# Altium schematic sheet A9_PERFBOARD.SchDoc
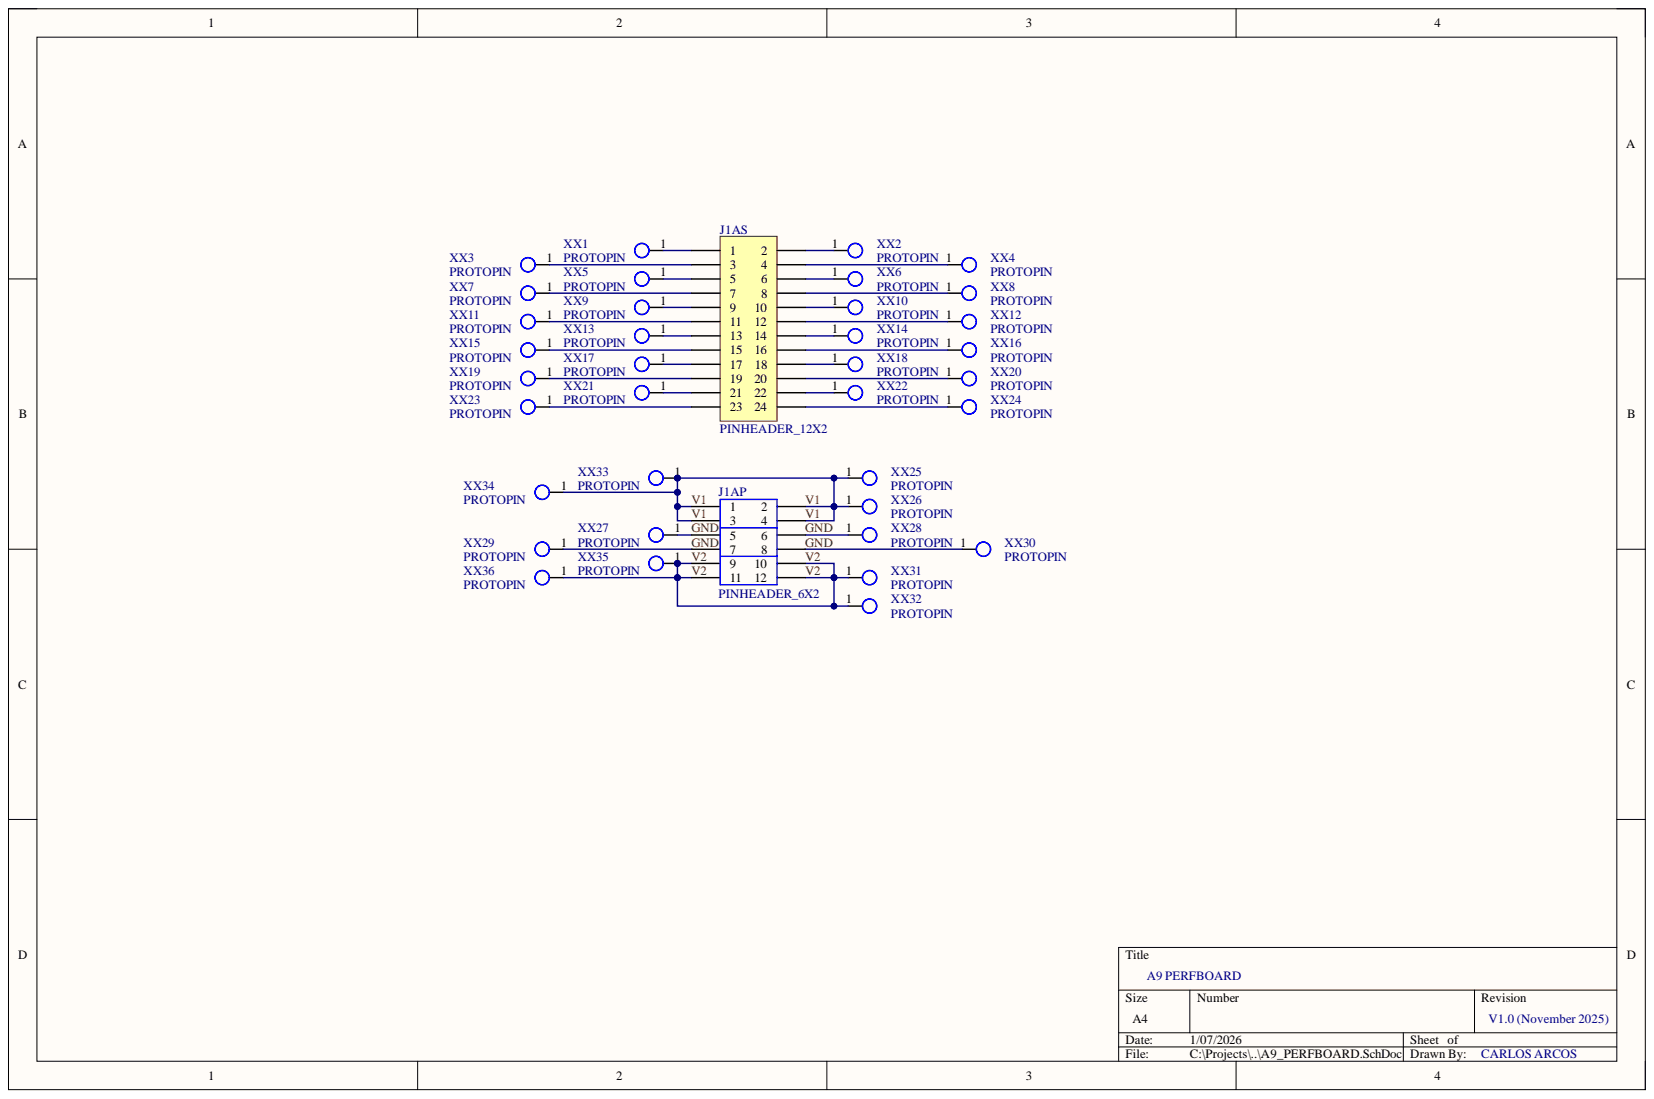
[diagram p0: A9_PERFBOARD.SchDoc]

## Parts
J1AP  PINHEADER_6X2  [PINHEADER_6X2]
J1AS  PINHEADER_12X2  [PINHEADER_12X2]
XX1  PROTOPIN  [PROTOPIN]
XX2  PROTOPIN  [PROTOPIN]
XX3  PROTOPIN  [PROTOPIN]
XX4  PROTOPIN  [PROTOPIN]
XX5  PROTOPIN  [PROTOPIN]
XX6  PROTOPIN  [PROTOPIN]
XX7  PROTOPIN  [PROTOPIN]
XX8  PROTOPIN  [PROTOPIN]
XX9  PROTOPIN  [PROTOPIN]
XX10  PROTOPIN  [PROTOPIN]
XX11  PROTOPIN  [PROTOPIN]
XX12  PROTOPIN  [PROTOPIN]
XX13  PROTOPIN  [PROTOPIN]
XX14  PROTOPIN  [PROTOPIN]
XX15  PROTOPIN  [PROTOPIN]
XX16  PROTOPIN  [PROTOPIN]
XX17  PROTOPIN  [PROTOPIN]
XX18  PROTOPIN  [PROTOPIN]
XX19  PROTOPIN  [PROTOPIN]
XX20  PROTOPIN  [PROTOPIN]
XX21  PROTOPIN  [PROTOPIN]
XX22  PROTOPIN  [PROTOPIN]
XX23  PROTOPIN  [PROTOPIN]
XX24  PROTOPIN  [PROTOPIN]
XX25  PROTOPIN  [PROTOPIN]
XX26  PROTOPIN  [PROTOPIN]
XX27  PROTOPIN  [PROTOPIN]
XX28  PROTOPIN  [PROTOPIN]
XX29  PROTOPIN  [PROTOPIN]
XX30  PROTOPIN  [PROTOPIN]
XX31  PROTOPIN  [PROTOPIN]
XX32  PROTOPIN  [PROTOPIN]
XX33  PROTOPIN  [PROTOPIN]
XX34  PROTOPIN  [PROTOPIN]
XX35  PROTOPIN  [PROTOPIN]
XX36  PROTOPIN  [PROTOPIN]

## Nets
GND: J1AP.5 J1AP.6 J1AP.7 J1AP.8 XX27.1 XX28.1 XX29.1 XX30.1
V1: J1AP.1 J1AP.2 J1AP.3 J1AP.4 XX25.1 XX26.1 XX33.1 XX34.1
V2: J1AP.9 J1AP.10 J1AP.11 J1AP.12 XX31.1 XX32.1 XX35.1 XX36.1
NetJ1AS_1: J1AS.1 XX1.1
NetJ1AS_2: J1AS.2 XX2.1
NetJ1AS_3: J1AS.3 XX3.1
NetJ1AS_4: J1AS.4 XX4.1
NetJ1AS_5: J1AS.5 XX5.1
NetJ1AS_6: J1AS.6 XX6.1
NetJ1AS_7: J1AS.7 XX7.1
NetJ1AS_8: J1AS.8 XX8.1
NetJ1AS_9: J1AS.9 XX9.1
NetJ1AS_10: J1AS.10 XX10.1
NetJ1AS_11: J1AS.11 XX11.1
NetJ1AS_12: J1AS.12 XX12.1
NetJ1AS_13: J1AS.13 XX13.1
NetJ1AS_14: J1AS.14 XX14.1
NetJ1AS_15: J1AS.15 XX15.1
NetJ1AS_16: J1AS.16 XX16.1
NetJ1AS_17: J1AS.17 XX17.1
NetJ1AS_18: J1AS.18 XX18.1
NetJ1AS_19: J1AS.19 XX19.1
NetJ1AS_20: J1AS.20 XX20.1
NetJ1AS_21: J1AS.21 XX21.1
NetJ1AS_22: J1AS.22 XX22.1
NetJ1AS_23: J1AS.23 XX23.1
NetJ1AS_24: J1AS.24 XX24.1

## Library data of the parts
J1AP = PINHEADER_6X2: Component Kind: Standard; Library Name: ISO216PCB.SchLib
J1AS = PINHEADER_12X2: Component Kind: Standard; Library Name: ISO216PCB.SchLib
XX1-XX36 = PROTOPIN: Component Kind: Standard; Library Name: ISO216PCB.SchLib
